# Altium schematic sheet Transmitter.SchDoc
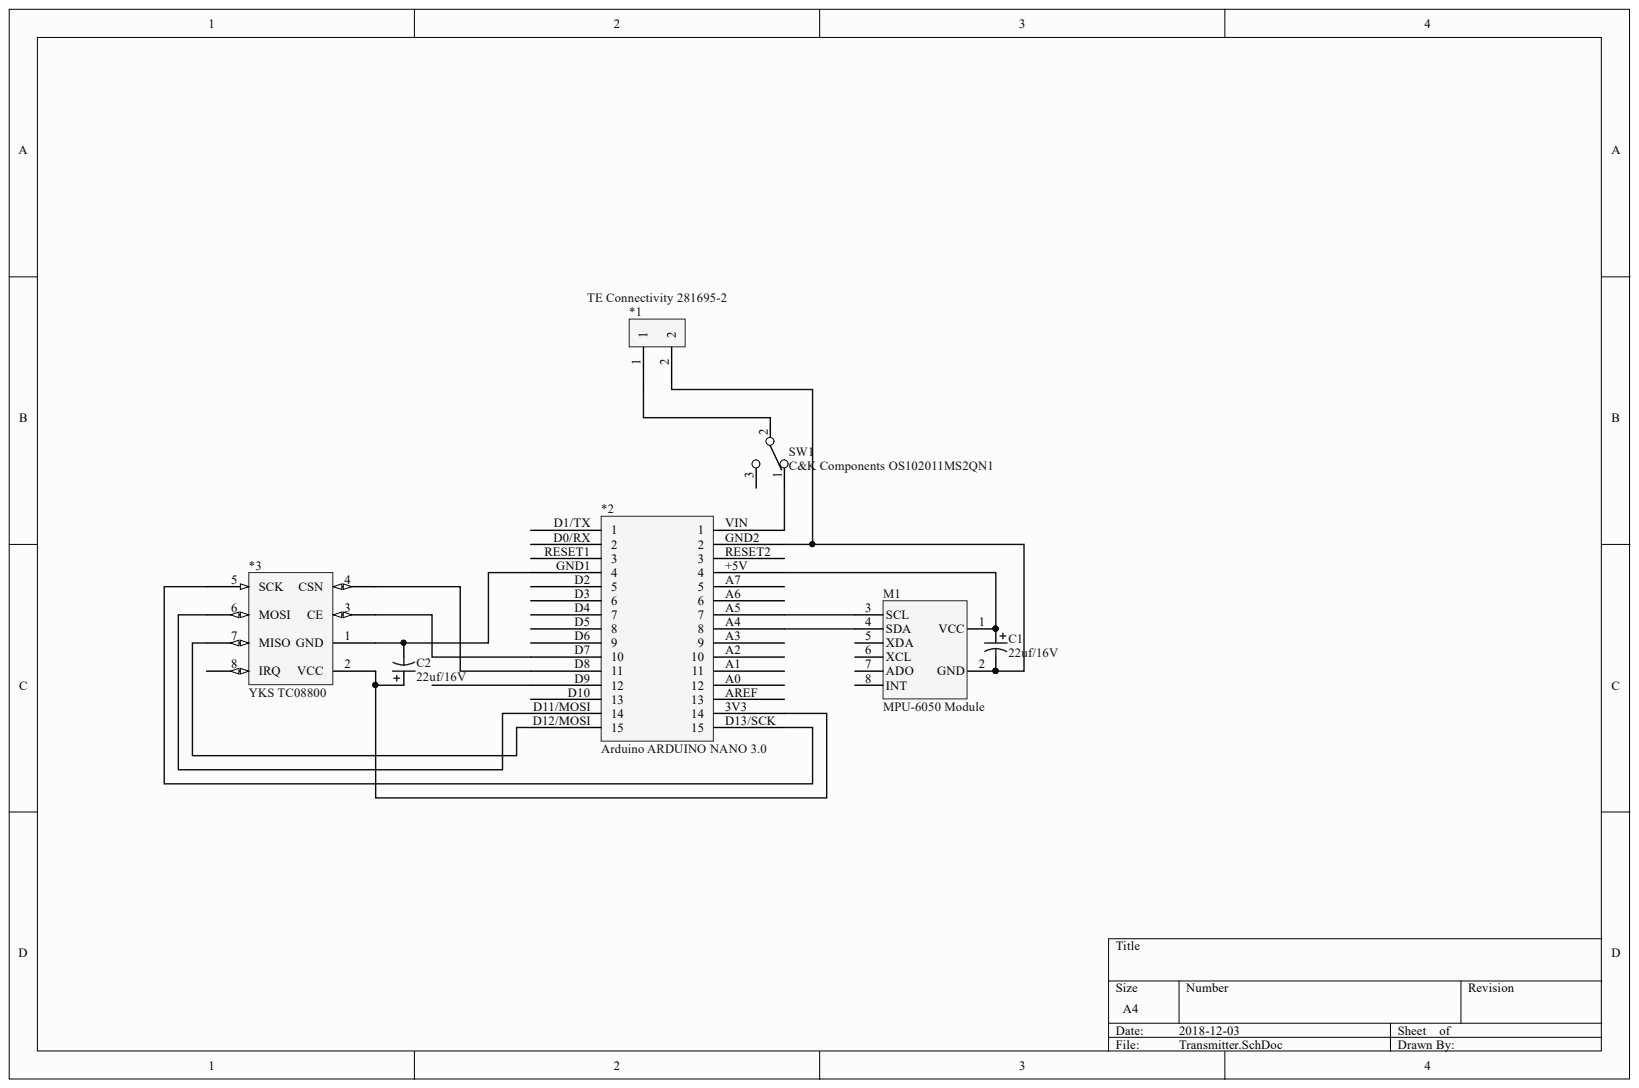
[diagram p0: Transmitter.SchDoc]

## Parts
*1  TE Connectivity 281695-2  [PCB-55uug6ujkbv21exb3umc-1]
*2  Arduino ARDUINO NANO 3.0  [PCBComponent_1 - duplicate]
*3  YKS TC08800  [PCB-pr7ep0xgk71wl34p5oug-1]
C1  22uf/16V  [PCB-w1mz1f6kibge3ckcia7t-1]
C2  22uf/16V  [PCB-w1mz1f6kibge3ckcia7t-1]
M1  MPU-6050 Module  [PCB-hzred797r614sh1cob2d-1]
SW1  C&K Components OS102011MS2QN1  [PCB-5zgzxay76ut73c17ajyg-1]

## Nets
Net*1_1: *1.1 SW1.2
Net*1_2: *1.2 *2.GND2 C1.2 M1.2(GND)
Net*2_3V3: *2.3V3 *3.2(VCC) C2.1
Net*2_+5V: *2.+5V C1.1 M1.1(VCC)
Net*2_A4: *2.A4 M1.4(SDA)
Net*2_A5: *2.A5 M1.3(SCL)
Net*2_D7: *2.D7 *3.3(CE)
Net*2_D8: *2.D8 *3.4(CSN)
Net*2_D11/MOSI: *2.D11/MOSI *3.6(MOSI)
Net*2_D12/MOSI: *2.D12/MOSI *3.7(MISO)
Net*2_D13/SCK: *2.D13/SCK *3.5(SCK)
Net*2_GND1: *2.GND1 *3.1(GND) C2.2
Net*2_VIN: *2.VIN SW1.1

Not connected: *2 A0 A1 A2 A3 A6 A7 AREF D0/RX D1/TX D2 D3 D4 D5 D6 D9 D10 RESET1 RESET2; *3 8(IRQ); M1 5(XDA) 6(XCL) 7(ADO) 8(INT); SW1 3

## Library data of the parts
*1 = 281695-2: AMPMODU HE14 Series, 2.54mm Pitch 2 Way 1 Row Straight PCB Header, Solder Term; Gender: Male; Lead-Free Status: Lead Free; Number of Positions: 2; Component Kind: Standard; Contact Plating: Tin, Tin over Nickel; Housing Color: Blue; Housing Material: Thermoplastic; Library Name: Octopart library; Manufacturer Part Number: 281695-2; Mounting Style: Through Hole; OctopartID: e5782e1080433abf; Octopart URL: https://octopart.com/281695-2-te+connectivity-39993437; Operating Temperature: -55.0 °C to 105 °C; Orientation: Vertical; Packaging: Bulk; REACH SVHC Compliance: No SVHC; RoHS: Compliant
*2 = ARDUINO NANO 3.0: Arduino Nano; Component Kind: Standard; Library Name: Octopart library; Manufacturer Part Number: ARDUINO NANO
*3 = TC08800: Arduino NRF24L01+ 2.4GHz Antenna Wireless Transceiver Module For Microcontroll; Component Kind: Standard; Library Name: Octopart library; OctopartID: fde03023fed42227; Octopart URL: http://octopart.com/tc08800-yks-80291410
C1 C2 = UPW1C100MDD: Cap Aluminum Lytic 22uF 16V 20% (5 X 11mm) Radial 2mm 180mA 2000h 105?C Automotive Bulk; Component Kind: Standard; Library Name: Octopart library; OctopartID: 295de054142df69a
M1 = SEN0142: Sensor: position; 3÷5VDC; IC: MPU-6050; Interface: I2C; Component Kind: Standard; Library Name: Octopart library; Manufacturer Part Number: SEN0142; OctopartID: 7757bf844852a890; Octopart URL: http://octopart.com/sen0142-dfrobot-31005283
SW1 = OS102011MS2QN1: Switch Slide ON ON SPDT Top Slide 0.1A 12VDC 10000Cycles PC Pins Thru-Hole Bulk; Contact Resistance: 20.0 mΩ; Current Rating: 200 mA; Insulation Resistance: 100 MΩ; Lead-Free Status: Lead Free; Component Kind: Standard; Contacts Type: SPDT; Library Name: Octopart library; Manufacturer Part Number: OS102011MS2QN1; Mounting Style: PCB, Through Hole; OctopartID: 48a681899f6c9761; Octopart URL: http://octopart.com/os102011ms2qn1-c%26k+components-2486956; Orientation: Vertical; REACH SVHC Compliance: No SVHC; RoHS: Compliant
